# Altium schematic sheet Primary.SchDoc
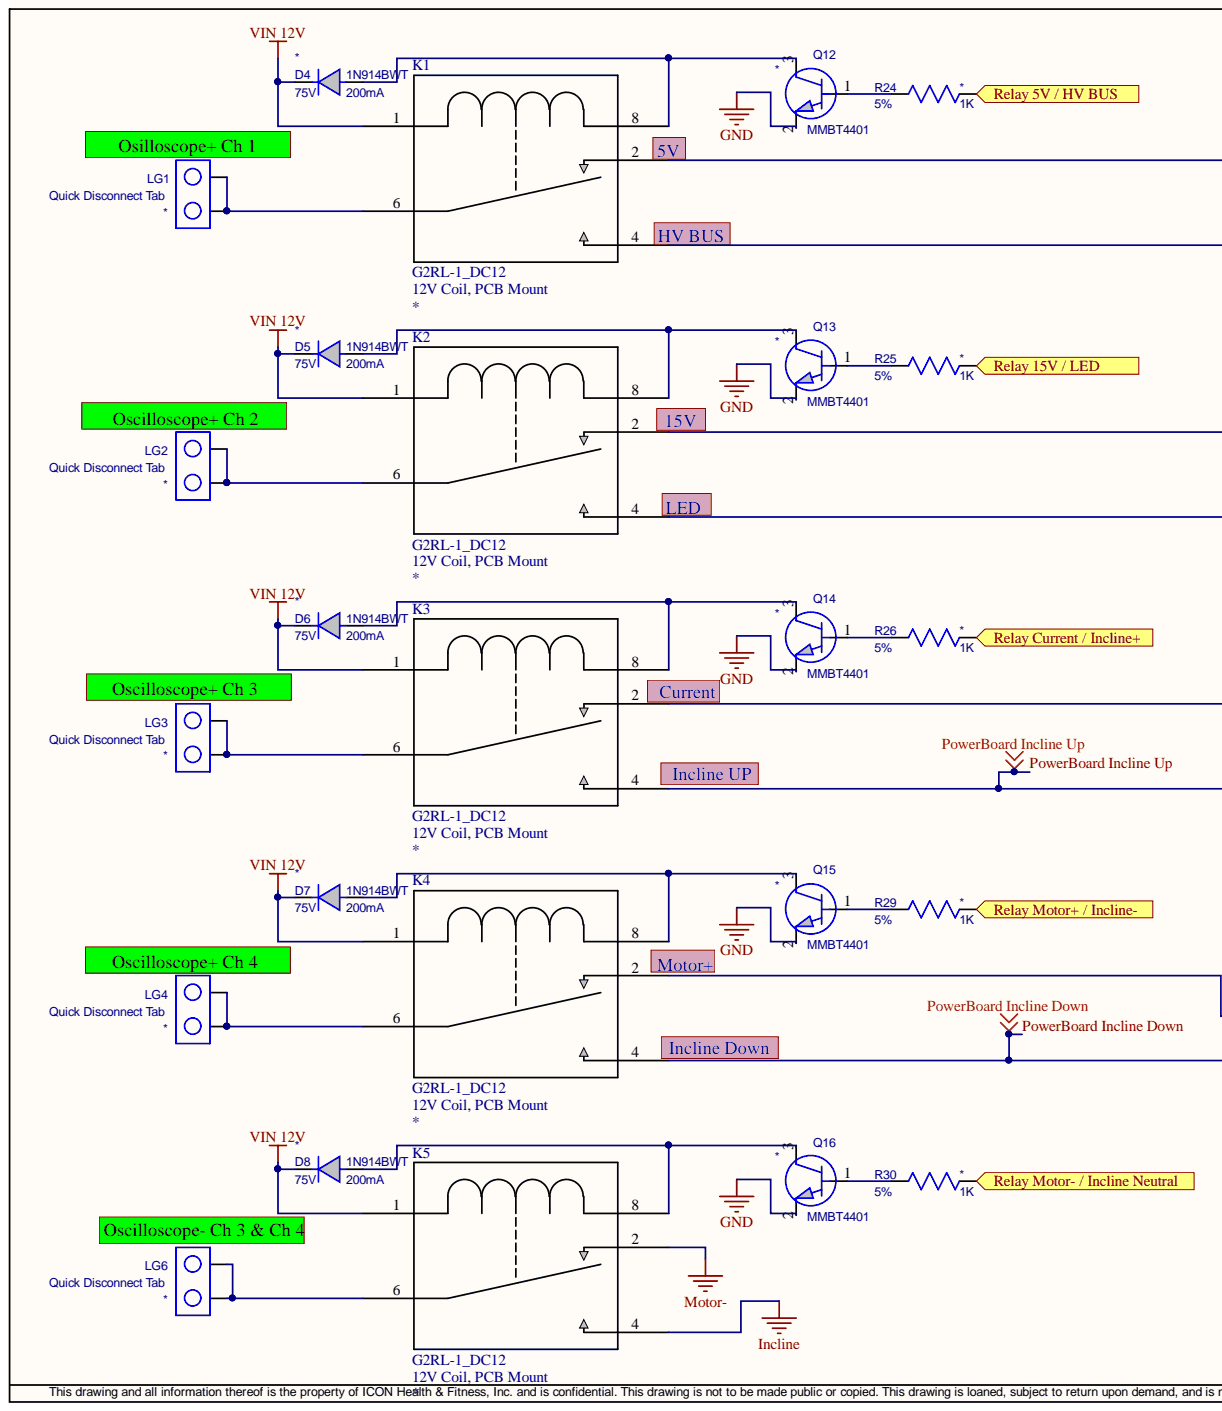
[diagram p0: Primary.SchDoc, left]
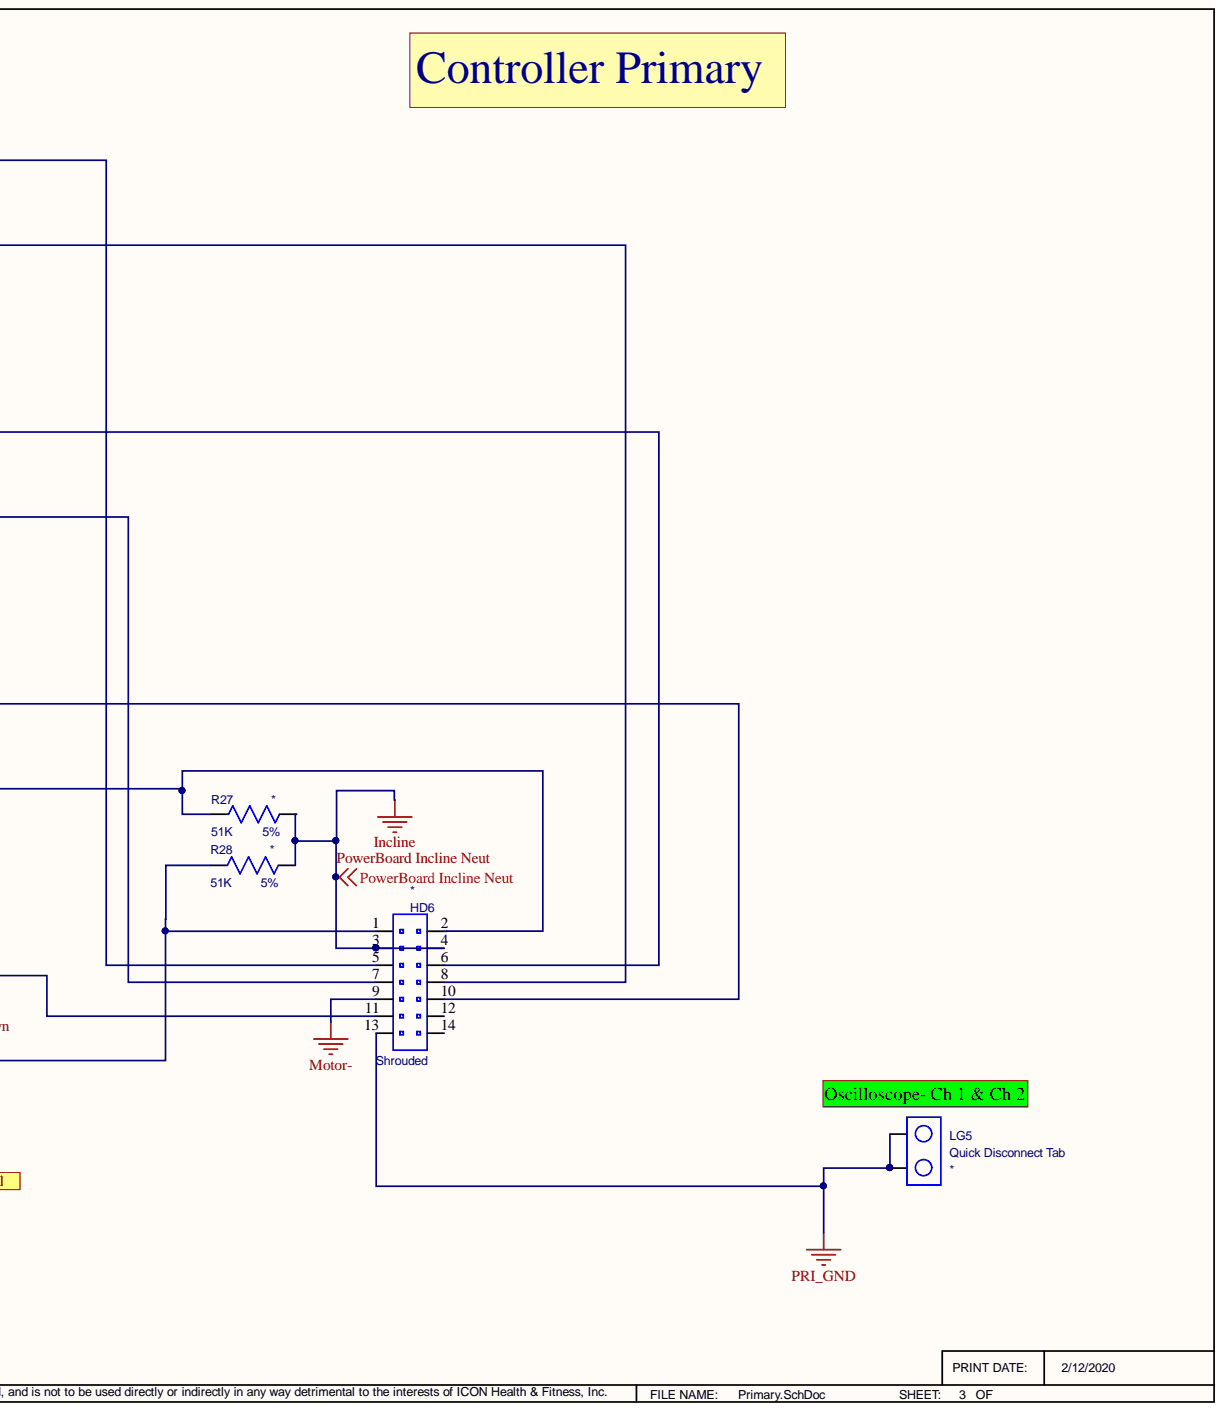
[diagram p1: Primary.SchDoc, right]

## Parts
D4  value 1N914BWT  Amperage: 200mA  Voltage: 75V  [SOD-523F]  (hidden comment: DIODE_SMT)
D5  value 1N914BWT  Amperage: 200mA  Voltage: 75V  [SOD-523F]  (hidden comment: DIODE_SMT)
D6  value 1N914BWT  Amperage: 200mA  Voltage: 75V  [SOD-523F]  (hidden comment: DIODE_SMT)
D7  value 1N914BWT  Amperage: 200mA  Voltage: 75V  [SOD-523F]  (hidden comment: DIODE_SMT)
D8  value 1N914BWT  Amperage: 200mA  Voltage: 75V  [SOD-523F]  (hidden comment: DIODE_SMT)
HD6  HeaderType: Shrouded  [HDR_2.5MM_14PIN_JST_XAD]  (hidden comment: HDR_14PIN_DUALROW_JST)
K1  G2RL-1_DC12  value 12V Coil, PCB Mount  [RELAY_G2RL-1_DC12]
K2  G2RL-1_DC12  value 12V Coil, PCB Mount  [RELAY_G2RL-1_DC12]
K3  G2RL-1_DC12  value 12V Coil, PCB Mount  [RELAY_G2RL-1_DC12]
K4  G2RL-1_DC12  value 12V Coil, PCB Mount  [RELAY_G2RL-1_DC12]
K5  G2RL-1_DC12  value 12V Coil, PCB Mount  [RELAY_G2RL-1_DC12]
LG1  value Quick Disconnect Tab  [SPADE_0.25]  (hidden comment: SPADE_LUG)
LG2  value Quick Disconnect Tab  [SPADE_0.25]  (hidden comment: SPADE_LUG)
LG3  value Quick Disconnect Tab  [SPADE_0.25]  (hidden comment: SPADE_LUG)
LG4  value Quick Disconnect Tab  [SPADE_0.25]  (hidden comment: SPADE_LUG)
LG5  value Quick Disconnect Tab  [SPADE_0.25]  (hidden comment: SPADE_LUG)
LG6  value Quick Disconnect Tab  [SPADE_0.25]  (hidden comment: SPADE_LUG)
Q12  value MMBT4401  [SOT23-3]  (hidden comment: TRANSISTOR_NPN_SOT23)
Q13  value MMBT4401  [SOT23-3]  (hidden comment: TRANSISTOR_NPN_SOT23)
Q14  value MMBT4401  [SOT23-3]  (hidden comment: TRANSISTOR_NPN_SOT23)
Q15  value MMBT4401  [SOT23-3]  (hidden comment: TRANSISTOR_NPN_SOT23)
Q16  value MMBT4401  [SOT23-3]  (hidden comment: TRANSISTOR_NPN_SOT23)
R24  value 1K  Tolerance: 5%  [0402]  (hidden comment: RESISTOR_SMT_5%)
R25  value 1K  Tolerance: 5%  [0402]  (hidden comment: RESISTOR_SMT_5%)
R26  value 1K  Tolerance: 5%  [0402]  (hidden comment: RESISTOR_SMT_5%)
R27  value 51K  Tolerance: 5%  [RC0603REFLOW-IPC]  (hidden comment: R0603REFLOW_5%)
R28  value 51K  Tolerance: 5%  [RC0603REFLOW-IPC]  (hidden comment: R0603REFLOW_5%)
R29  value 1K  Tolerance: 5%  [0402]  (hidden comment: RESISTOR_SMT_5%)
R30  value 1K  Tolerance: 5%  [0402]  (hidden comment: RESISTOR_SMT_5%)

## Ports
Relay 5V / HV BUS, Relay 15V / LED, Relay Current / Incline+, Relay Motor+ / Incline-, Relay Motor- / Incline Neutral

## Nets
GND: Q12.2 Q13.2 Q14.2 Q15.2 Q16.2
Motor-: HD6.9 K5.2
PRI_GND: HD6.13 LG5.1 LG5.2
VIN 12V: D4.1 D5.1 D6.1 D7.1 D8.1 K1.1 K2.1 K3.1 K4.1 K5.1
PowerBoard Incline Down: HD6.1 K4.4 R28.2
PowerBoard Incline Neut: HD6.3 HD6.4 K5.4 R27.1 R28.1
PowerBoard Incline Up: HD6.2 K3.4 R27.2
Relay 5V / HV BUS: R24.1 ; port Relay 5V / HV BUS
Relay 15V / LED: R25.1 ; port Relay 15V / LED
Relay Current / Incline+: R26.1 ; port Relay Current / Incline+
Relay Motor+ / Incline-: R29.1 ; port Relay Motor+ / Incline-
Relay Motor- / Incline Neutral: R30.1 ; port Relay Motor- / Incline Neutral
NetD4_2: D4.2 K1.8 Q12.3
NetD5_2: D5.2 K2.8 Q13.3
NetD6_2: D6.2 K3.8 Q14.3
NetD7_2: D7.2 K4.8 Q15.3
NetD8_2: D8.2 K5.8 Q16.3
NetHD6_5: HD6.5 K1.2
NetHD6_6: HD6.6 K2.2
NetHD6_7: HD6.7 K2.4
NetHD6_8: HD6.8 K1.4
NetHD6_10: HD6.10 K3.2
NetHD6_11: HD6.11 K4.2
NetK1_6: K1.6 LG1.1 LG1.2
NetK2_6: K2.6 LG2.1 LG2.2
NetK3_6: K3.6 LG3.1 LG3.2
NetK4_6: K4.6 LG4.1 LG4.2
NetK5_6: K5.6 LG6.1 LG6.2
NetQ12_1: Q12.1 R24.2
NetQ13_1: Q13.1 R25.2
NetQ14_1: Q14.1 R26.2
NetQ15_1: Q15.1 R29.2
NetQ16_1: Q16.1 R30.2

Not connected: HD6 12 14

## Library data of the parts
D4-D8 = 16165: Diode, 1N914BWT, Fast Switching, 75V, 200mA, SOD-523F, Fairchild: 1N914BWT; Database Table Name: AltiumComponents; Wattage: 200mW; Component Kind: Standard; ComponentLink4Description: PDM Parts Maintenance; ComponentLink4URL: https://pdm.iconfitness.com/PDM/EBOM/PartsMaintenance/PartsMaintenance.aspx?Editplidx=16165; ComponentType: Diode; Cost: 0.01; DiodeType: Fast Switching; Package: SOD-523F; plIDX: 16165; Vendor: Fairchild: 1N914BWT; CheckedBy: gdeguzman; LastUpdatedBy: Mike Tyger; Library Name: bome.DbLib
HD6 = 365385: Header, 14 Pins, 2.50mm, Vertical, Through hole, double row, Shrouded, JST: B14B-XADSS-N-A; Database Table Name: AltiumComponents; Component Kind: Standard; ComponentLink1Description: Datasheet; ComponentLink1URL: \\iconfitness.com\loganfiles\RND Departments\electronics\accel_lib\Datasheets\eXA1.pdf; ComponentLink4Description: PDM Parts Maintenance; ComponentLink4URL: https://pdm.iconfitness.com/PDM/EBOM/PartsMaintenance/PartsMaintenance.aspx?Editplidx=365385; ComponentType: Header; Cost: 0; LeadSpacing: 2.50mm; Package: Vertical, Through hole, double row; Pins: 14; plIDX: 365385; Value: B14B-XADSS-N-A; Vendor: JST: B14B-XADSS-N-A; CreatedBy: Ross Bunnell; LastUpdatedBy: Ross Bunnell; Library Name: bome.DbLib
K1-K5 = 365383: Relay, 12V Coil, PCB Mount, 440VAC, 12A, 5, SPDT, Omron: G2RL-1 DC12; Or Equivalent; Database Table Name: AltiumComponents; Amperage: 12A; Circuit: SPDT; Component Kind: Standard; ComponentLink4Description: PDM Parts Maintenance; ComponentLink4URL: https://pdm.iconfitness.com/PDM/EBOM/PartsMaintenance/PartsMaintenance.aspx?Editplidx=365383; ComponentType: Relay; Cost: 0; Pins: 5; plIDX: 365383; Vendor: Omron: G2RL-1 DC12; Or Equivalent; Voltage: 440VAC; CreatedBy: Ross Bunnell; LastUpdatedBy: Ross Bunnell; Library Name: bome.DbLib
LG1-LG6 = 265185: Header, 0.25", Ting Zi: 025-3489-01 or equivalent; Database Table Name: AltiumComponents; Component Kind: Standard; ComponentLink1Description: Datasheet; ComponentLink1URL: \\iconfitness.com\loganfiles\RND Departments\Electronics\accel_lib\025-3489-01.pdf; ComponentLink4Description: PDM Parts Maintenance; ComponentLink4URL: https://pdm.iconfitness.com/PDM/EBOM/PartsMaintenance/PartsMaintenance.aspx?Editplidx=265185; ComponentType: Header; Cost: 0.0125; HeaderType: 0.25"; plIDX: 265185; Vendor: Ting Zi: 025-3489-01 or equivalent; CheckedBy: gdeguzman; CreatedBy: Mike Tyger; LastUpdatedBy: Mike Tyger; Library Name: bome.DbLib
Q12-Q16 = 265442: Transistor, MMBT4401, 40V, SOT23-3, 500mA, NPN, Transistor, On Semi: MMBT4401LT1; Database Table Name: AltiumComponents; Amperage: 500mA; ChipType: Transistor; Component Kind: Standard; ComponentLink4Description: PDM Parts Maintenance; ComponentLink4URL: https://pdm.iconfitness.com/PDM/EBOM/PartsMaintenance/PartsMaintenance.aspx?Editplidx=265442; ComponentType: Transistor; Cost: 0.0094; Package: SOT23-3; plIDX: 265442; TransistorType: NPN; Vendor: On Semi: MMBT4401LT1; Voltage: 40V; CheckedBy: gdeguzman; CreatedBy: Mike Tyger; LastUpdatedBy: Mike Tyger; Library Name: bome.DbLib
R24-R26 R29 R30 = 22012: Resistor, 1K, ThickFilm, 5%, 0402, 1/10W, Any; Database Table Name: AltiumComponents; Component Kind: Standard; ComponentLink4Description: PDM Parts Maintenance; ComponentLink4URL: https://pdm.iconfitness.com/PDM/EBOM/PartsMaintenance/PartsMaintenance.aspx?Editplidx=22012; ComponentType: Resistor; Composition: ThickFilm; Cost: 0.001; Package: 0402; plIDX: 22012; Vendor: Any; Wattage: 1/10W; CheckedBy: gdeguzman; LastUpdatedBy: Mike Tyger; Library Name: bome.DbLib
R27 R28 = 2418: Resistor, 51K, ThickFilm, 5%, 0603, 1/10W, Any; Database Table Name: AltiumComponents; Notes: .; Component Kind: Standard; ComponentLink4Description: PDM Parts Maintenance; ComponentLink4URL: https://pdm.iconfitness.com/PDM/EBOM/PartsMaintenance/PartsMaintenance.aspx?Editplidx=2418; ComponentType: Resistor; Composition: ThickFilm; Cost: 0.0004; ModifyDate: 03/02/2004 17:13:13; Package: 0603; plIDX: 2418; Vendor: Any; Wattage: 1/10W; CheckedBy: Michael Angeli; LastUpdatedBy: Kimberly Barker; Library Name: bome.DbLib
